# Altium schematic sheet Peripherals.SchDoc(Peripherals)
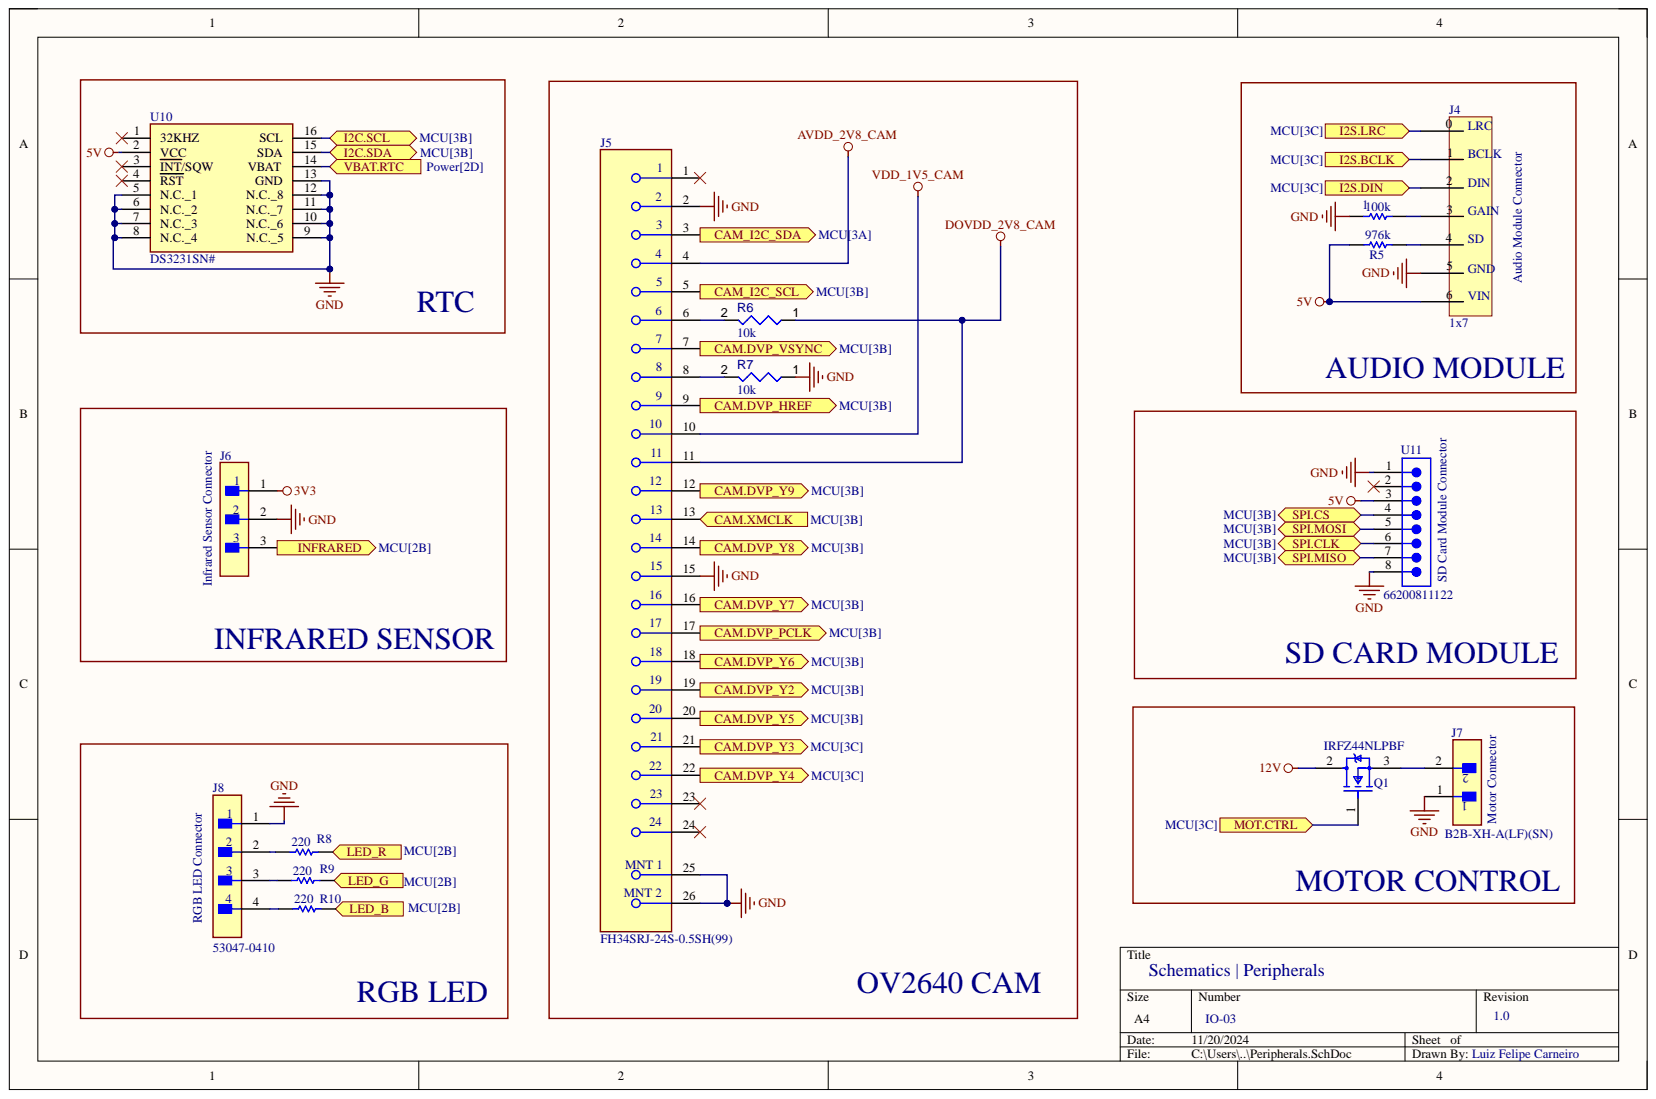
[diagram p0: Peripherals.SchDoc]

## Parts
1  value 100k  [RESC1608X55X25ML10T15]  (hidden comment: Resistor 0R +/- 5% 0603 100 mW)
J4  1x7  [MaleHeaderPTH1x7]
J5  FH34SRJ-24S-0.5SH(99)  [FP-FH34SRJ-24S-0_5SH_99-MFG]
J6  B3B-XH-A(LF)(SN)  [FP-B3B-XH-A_LF_SN-MFG]  (value not drawn)
J7  B2B-XH-A(LF)(SN)  [FP-B2B-XH-A_LF_SN-MFG]
J8  53047-0410  [FP-53047-0410-MFG]
Q1  IRFZ44NLPBF  [FP-PG-TO262-3-MFG]
R5  value 976k  [RESC1608X55X25ML10T15]  (hidden comment: Resistor 0R +/- 5% 0603 100 mW)
R6  value 10k  [RESC0402(1005)_L]  (hidden comment: Resistor 1K +/-5 % 0402 63 mW)
R7  value 10k  [RESC0402(1005)_L]  (hidden comment: Resistor 1K +/-5 % 0402 63 mW)
R8  value 220  [RESC1608X55X25ML10T15]  (hidden comment: Resistor 0R +/- 5% 0603 100 mW)
R9  value 220  [RESC1608X55X25ML10T15]  (hidden comment: Resistor 0R +/- 5% 0603 100 mW)
R10  value 220  [RESC1608X55X25ML10T15]  (hidden comment: Resistor 0R +/- 5% 0603 100 mW)
U10  DS3231SN#  [SOIC127P1032X265-16N]
U11  66200811122  [66200811122]

## Ports
CAM.DVP_HREF, CAM.DVP_PCLK, CAM.DVP_VSYNC, CAM.DVP_Y2, CAM.DVP_Y3, CAM.DVP_Y4, CAM.DVP_Y5, CAM.DVP_Y6, CAM.DVP_Y7, CAM.DVP_Y8, CAM.DVP_Y9, CAM.XMCLK, CAM_I2C_SCL, CAM_I2C_SDA, I2C.SCL, I2C.SDA, I2S.BCLK, I2S.DIN, I2S.LRC, INFRARED, LED_B, LED_G, LED_R, MOT.CTRL, SPI.CLK, SPI.CS, SPI.MISO, SPI.MOSI, VBAT.RTC

## Nets
3V3: J6.1
5V: J4.6(VIN) R5.1 U10.2(VCC) U11.3
12V: Q1.2
AVDD_2V8_CAM: J5.4
DOVDD_2V8_CAM: J5.11 R6.1
GND: 1.2 J4.5 J5.2 J5.15 J5.25 J5.26 J6.2 J7.1 J8.1 R7.1 U10.5(N.C._1) U10.6(N.C._2) U10.7(N.C._3) U10.8(N.C._4) U10.9(N.C._5) U10.10(N.C._6) U10.11(N.C._7) U10.12(N.C._8) U10.13(GND) U11.1 U11.8
VDD_1V5_CAM: J5.10
CAM.DVP_HREF: J5.9 ; port CAM.DVP_HREF
CAM.DVP_PCLK: J5.17 ; port CAM.DVP_PCLK
CAM.DVP_VSYNC: J5.7 ; port CAM.DVP_VSYNC
CAM.DVP_Y2: J5.19 ; port CAM.DVP_Y2
CAM.DVP_Y3: J5.21 ; port CAM.DVP_Y3
CAM.DVP_Y4: J5.22 ; port CAM.DVP_Y4
CAM.DVP_Y5: J5.20 ; port CAM.DVP_Y5
CAM.DVP_Y6: J5.18 ; port CAM.DVP_Y6
CAM.DVP_Y7: J5.16 ; port CAM.DVP_Y7
CAM.DVP_Y8: J5.14 ; port CAM.DVP_Y8
CAM.DVP_Y9: J5.12 ; port CAM.DVP_Y9
CAM.XMCLK: J5.13 ; port CAM.XMCLK
CAM_I2C_SCL: J5.5 ; port CAM_I2C_SCL
CAM_I2C_SDA: J5.3 ; port CAM_I2C_SDA
I2C.SCL: U10.16(SCL) ; port I2C.SCL
I2C.SDA: U10.15(SDA) ; port I2C.SDA
I2S.BCLK: J4.1 ; port I2S.BCLK
I2S.DIN: J4.2(DIN) ; port I2S.DIN
I2S.LRC: J4.0(LRC) ; port I2S.LRC
INFRARED: J6.3 ; port INFRARED
LED_B: R10.1 ; port LED_B
LED_G: R9.1 ; port LED_G
LED_R: R8.1 ; port LED_R
MOT.CTRL: Q1.1 ; port MOT.CTRL
SPI.CLK: U11.6 ; port SPI.CLK
SPI.CS: U11.4 ; port SPI.CS
SPI.MISO: U11.7 ; port SPI.MISO
SPI.MOSI: U11.5 ; port SPI.MOSI
VBAT.RTC: U10.14(VBAT) ; port VBAT.RTC
Net1_1: 1.1 J4.3
NetJ4_4: J4.4(SD) R5.2
NetJ5_6: J5.6 R6.2
NetJ5_8: J5.8 R7.2
NetJ7_2: J7.2 Q1.3
NetJ8_2: J8.2 R8.2
NetJ8_3: J8.3 R9.2
NetJ8_4: J8.4 R10.2

Not connected: J5 1 23 24; U10 1(32KHZ) 3(INT/SQW) 4(RST); U11 2

## Library data of the parts
1 R5 R8-R10 = CMP-009-00006-5: Chip Resistor, 0 Ohm, 0.1 W, -55 to 155 degC, 0603 (1608 Metric); Power: 100mW; Voltage Rating: 50V; Case/Package: 0603; Component Kind: Standard; Max Operating Temperature: 155°C; Min Operating Temperature: -55°C; Mounting Technology: SM; Pins: 2; RoHS Compliant: True; Tolerance: 5%; Library Name: Luiz Felipe Carneiro De Oliveira
J4 = Male Header PTH 1x7: Component Kind: Standard; Library Name: MaleHeaderPTH1x7.SchLib
J5 = CMP-11609-000022-1: CONN FPC/FFC 24POS .5MM; Actuator Material: Nylon; Current Rating: 500mA; Number of Positions: 24; Pitch: 0.02inch; Voltage Rating: 50V; Component Kind: Standard; Contact Material: Bronze; Contact Plating: Gold; Flammability Rating: UL94 V-0; Housing Material: Polymer; Library Name: Altium Content Vault; Max Operating Temperature: 85°C; Min Operating Temperature: -55°C; Mount: Surface Mount; Number of Pins: 24; Packaging: Tape and Reel; RoHS Compliant: Yes; Termination: Solder
J6 = CMP-17439-000014-3: CONN HEADER VERT 3POS 2.5MM; Contact Resistance: 20mR; Current Rating: 3A; Gender: Male; Insulation Resistance: 1GR; Max Voltage Rating (AC): 250V; Number of Contacts: 3; Wire/Cable Gauge: 22AWG; Color: Natural; Component Kind: Standard; Connector Type: Header; Contact Finish - Mating: TIN; Contact Material: Brass; Contact Plating: Tin; Contact Shape: Square; Contact Type: Male Pin; Depth: 5.75mm; Fastening Type: Detent; Flammability Rating: UL94 V-0; Housing Color: White; Housing Material: Polyamide; Insulation Color: Natural; Insulation Material: Nylon; Lead Free: Lead Free; Lead Pitch: 2.5mm; Length: 9.9mm; Library Name: Altium Content Vault; Max Current Rating: 3A; Max Operating Temperature: 85°C; Max Voltage Rating (DC): 250V; Min Operating Temperature: -25°C; Mount: Through Hole; Number of Positions: 3; Number of Rows: 1; Orientation: Straight; Packaging: Bulk; Pitch: 2.5mm; REACH SVHC: No SVHC; Series: XH; Termination: Solder; Voltage Rating: 250V; Voltage Rating (AC): 250V
J7 = CMP-2000-05888-3: CONN HEADER VERT 2POS 2.5MM; Connector Type: Male Pin; Contact Resistance: 20mR; Current Rating: 3A; Insulation Resistance: 1GR; Max Voltage Rating (AC): 250V; Number of Contacts: 2; Wire/Cable Gauge: 22AWG; Color: Natural; Component Kind: Standard; Contact Material: Brass; Contact Plating: Tin; Contact Type: Male Pin; Depth: 5.75mm; Fastening Type: Detent; Housing Color: White; Housing Material: Polyamide; Lead Free: Lead Free; Lead Pitch: 2.5mm; Length: 7.4mm; Library Name: Altium Content Vault; Max Current Rating: 3A; Max Operating Temperature: 85°C; Max Voltage Rating (DC): 250V; Min Operating Temperature: -25°C; Mount: Through Hole; Number of Positions: 2; Number of Rows: 1; Orientation: Straight; Packaging: Bulk; Pitch: 2.5mm; REACH SVHC: No SVHC; Series: XH; Termination: Solder; Voltage Rating: 250V; Voltage Rating (AC): 250V
J8 = CMP-04776-000321-1: CONN HEADER VERT 4POS 1.25MM; Contact Resistance: 20mR; Current: 1A; Gender: Male; Glow Wire Compliant: Non-compliant; Insulation Resistance: 100MR; Max Voltage Rating (AC): 125V; Number of Circuits: 4; Plating: Tin; Case/Package: 0410; Color: Natural; Component Kind: Standard; Contact Material: Bronze; Contact Plating: Tin; Current Rating: 1A; Flammability Rating: UL94 V-0; Housing Color: Natural; Housing Material: Polyamide; Lead Free: Lead Free; Library Name: Altium Content Vault; Max Operating Temperature: 85°C; Min Operating Temperature: -40°C; Mount: Through Hole; Number of Contacts: 4; Number of Positions: 4; Number of Rows: 1; Orientation: Vertical; Package Quantity: 5000; Packaging: Tray; Pitch: 1.25mm; Radiation Hardening: No; RoHS Compliant: Yes; Series: PicoBlade; Termination: Solder; Voltage: 125V; Voltage Rating: 125V; Voltage Rating (AC): 125V; Voltage Rating (DC): 125V; Working Voltage: 125V
Q1 = CMP-00196-65874408-1: IRFZ44NLPBF Single N-Channel 55 V 17.5 mOhm 63 nC HEXFET® Power Mosfet - TO-262; Continuous Drain Current (ID): 49A; Drain to Source Breakdown Voltage: 55V; Drain to Source Resistance: 17.5mΩ; Fall Time: 45ns; Gate to Source Voltage (Vgs): 20V; Input Capacitance: 1.47nF; Max Junction Temperature (Tj): 175°C; Max Power Dissipation: 3.8W; Nominal Vgs: 4V; Number of Channels: 1; Power Dissipation: 110W; Rise Time: 60ns; Turn-Off Delay Time: 44ns; Turn-On Delay Time: 12ns; Case/Package: TO-262; Component Kind: Standard; Current Rating: 49A; Drain to Source Voltage (Vdss): 55V; Dual Supply Voltage: 55V; Element Configuration: Single; Height: 9.65mm; Lead Free: Contains Lead, Lead Free; Length: 10.668mm; Library Name: Altium Content Vault; Lifecycle Status: Production; Max Operating Temperature: 175°C; Min Operating Temperature: -55°C; Mount: Through Hole; NexarPartId: 65874408; Number of Elements: 1; Number of Pins: 3; On-State Resistance: 17.5mΩ; Package Quantity: 1000; Packaging: Bulk; Radiation Hardening: No; Rds On Max: 17.5mΩ; REACH SVHC: No SVHC; Resistance: 17.5MΩ; RoHS: Compliant; Schedule B: 8541290080, 8541290080; Termination: Through Hole; Threshold Voltage: 4V; Voltage Rating (DC): 55V; Width: 4.826mm
R6 R7 = CMP-009-00157-3: Chip Resistor, 1 kOhms, +/-5 %, 63 mW, -55 to 155 degC, 0402; Power: 63mW; Tolerance: 5%; Case/Package: 0402; Component Kind: Standard; Max Operating Temperature: 155°C; Min Operating Temperature: -55°C; Pins: 2; Library Name: EPTA Workspace
U10 = DS3231SN#: Integrated Circuit; Manufacturer_Name: Analog Devices; Arrow Part Number: DS3231SN#; Arrow Price/Stock: https://www.arrow.com/en/products/ds3231sn/analog-devices?utm_currency=USD&region=nac; Component Kind: Standard; Datasheet Link: https://datasheets.maximintegrated.com/en/ds/DS3231.pdf; Height: 2.65mm; Manufacturer_Part_Number: DS3231SN#; Mouser Part Number: 700-DS3231SN#; Mouser Price/Stock: https://www.mouser.co.uk/ProductDetail/Analog-Devices-Maxim-Integrated/DS3231SN?qs=1eQvB6Dk1vhUlr8%2FOrV0Fw%3D%3D
U11 = CMP-1502-00446-2: NexarPartId: 23024701; Connector Type: Male Pin, Socket; Contact Resistance: 10mΩ; Current Rating: 5A; Gender: Male; Insulation Resistance: 1GΩ; Number of Contacts: 8; Operating Supply Voltage: 250V; Pitch: 3mm; Color: Black; Component Kind: Standard; Contact Material: Copper; Contact Plating: Tin; Fastening Type: Ramp; Height: 11mm; Lead Pitch: 3mm; Length: 27.65mm; Library Name: Altium Content Vault; Max Operating Temperature: 105°C; Min Operating Temperature: -40°C; Mount: Through Hole; Number of Pins: 8; Number of Positions: 8; Number of Rows: 1; Orientation: Vertical; REACH SVHC: Unknown; RoHS: Compliant; Termination: Kinked Pin; Width: 27.65mm
